# Altium schematic sheet [03] - ARDUINO SCHEMATIC.SchDoc("[03] - ARDUINO SCHEMATIC")
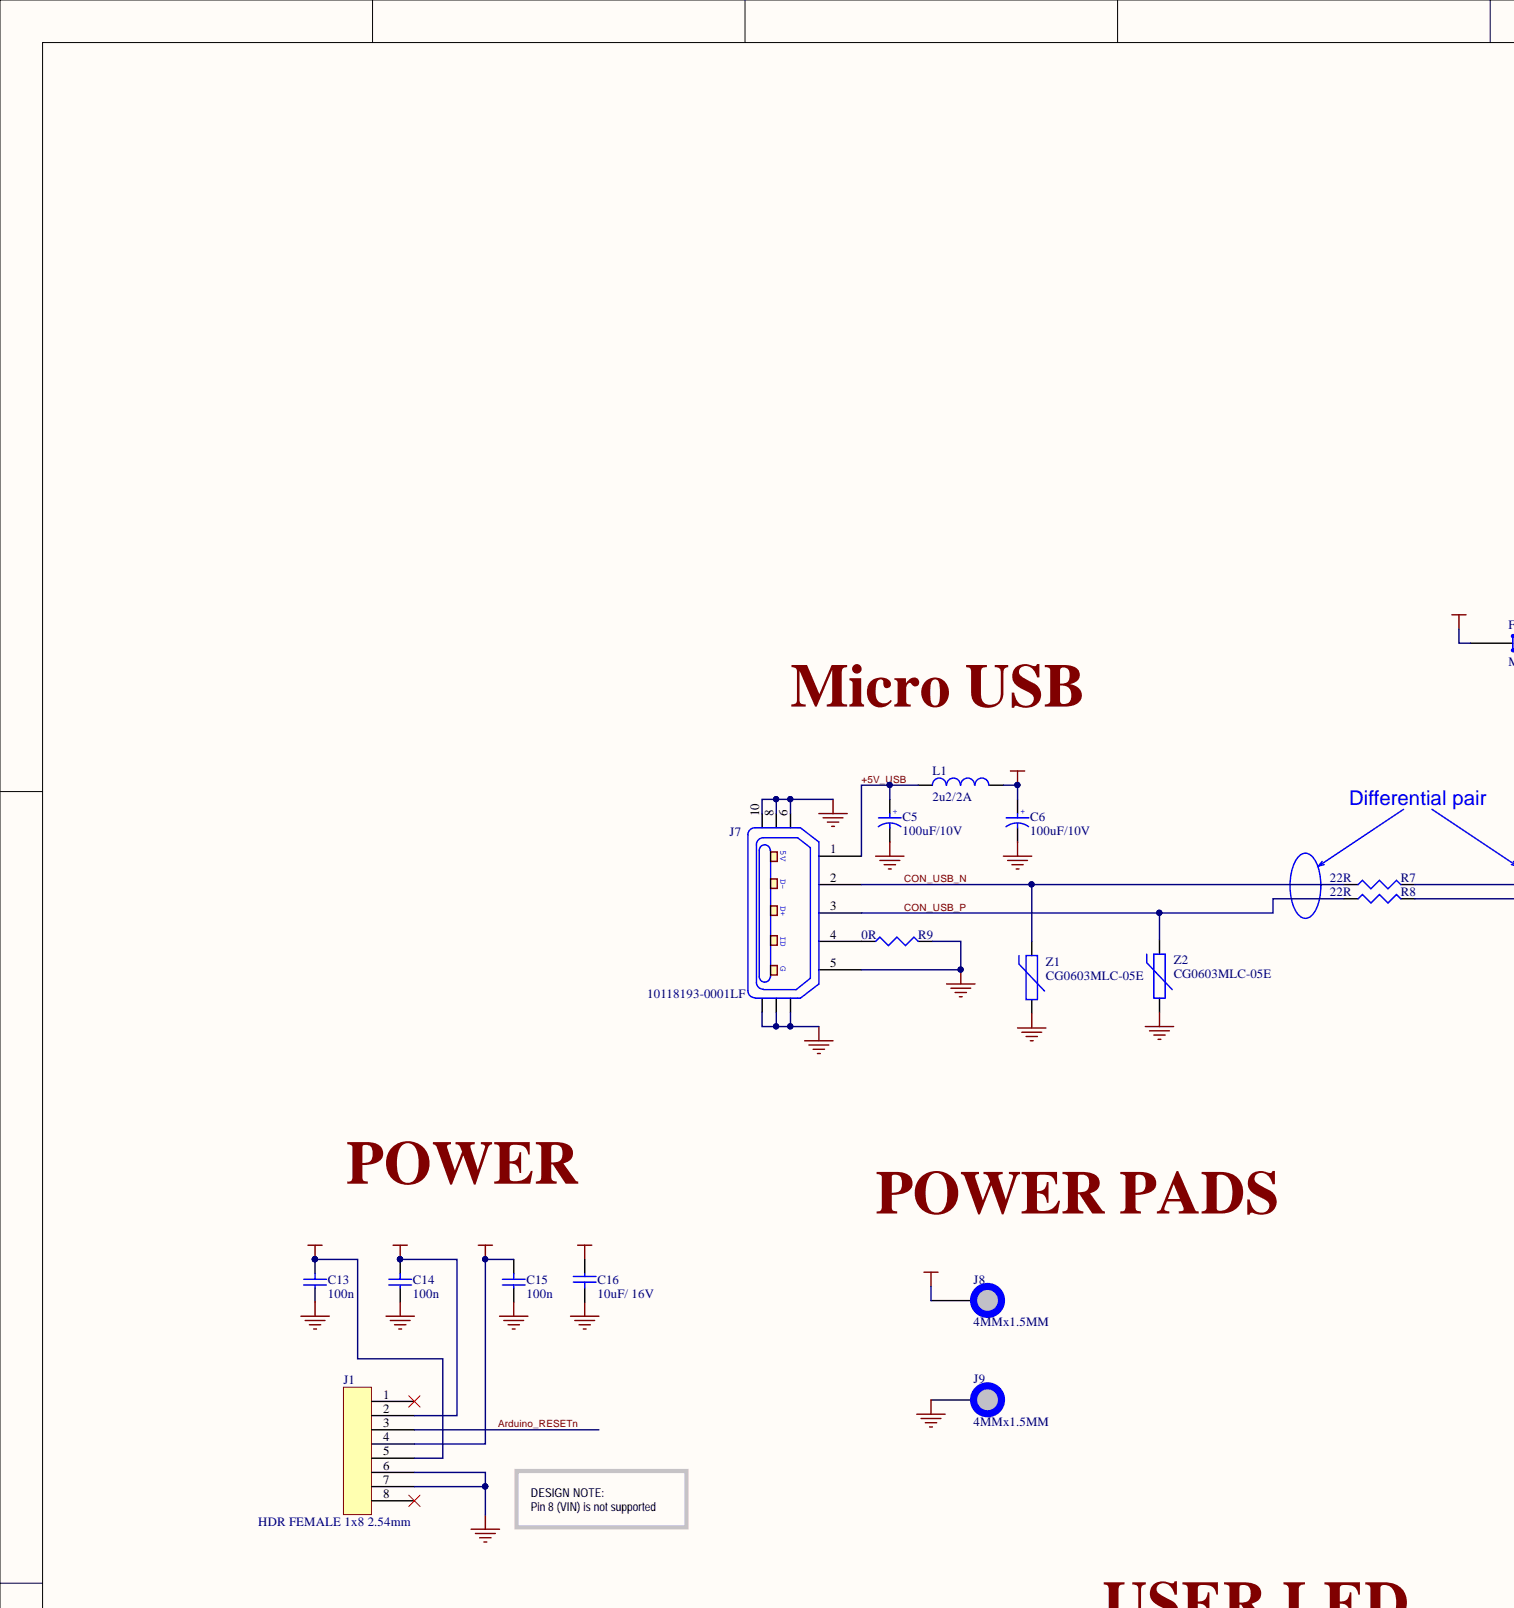
[diagram p0: [03] - ARDUINO SCHEMATIC.SchDoc, top left]
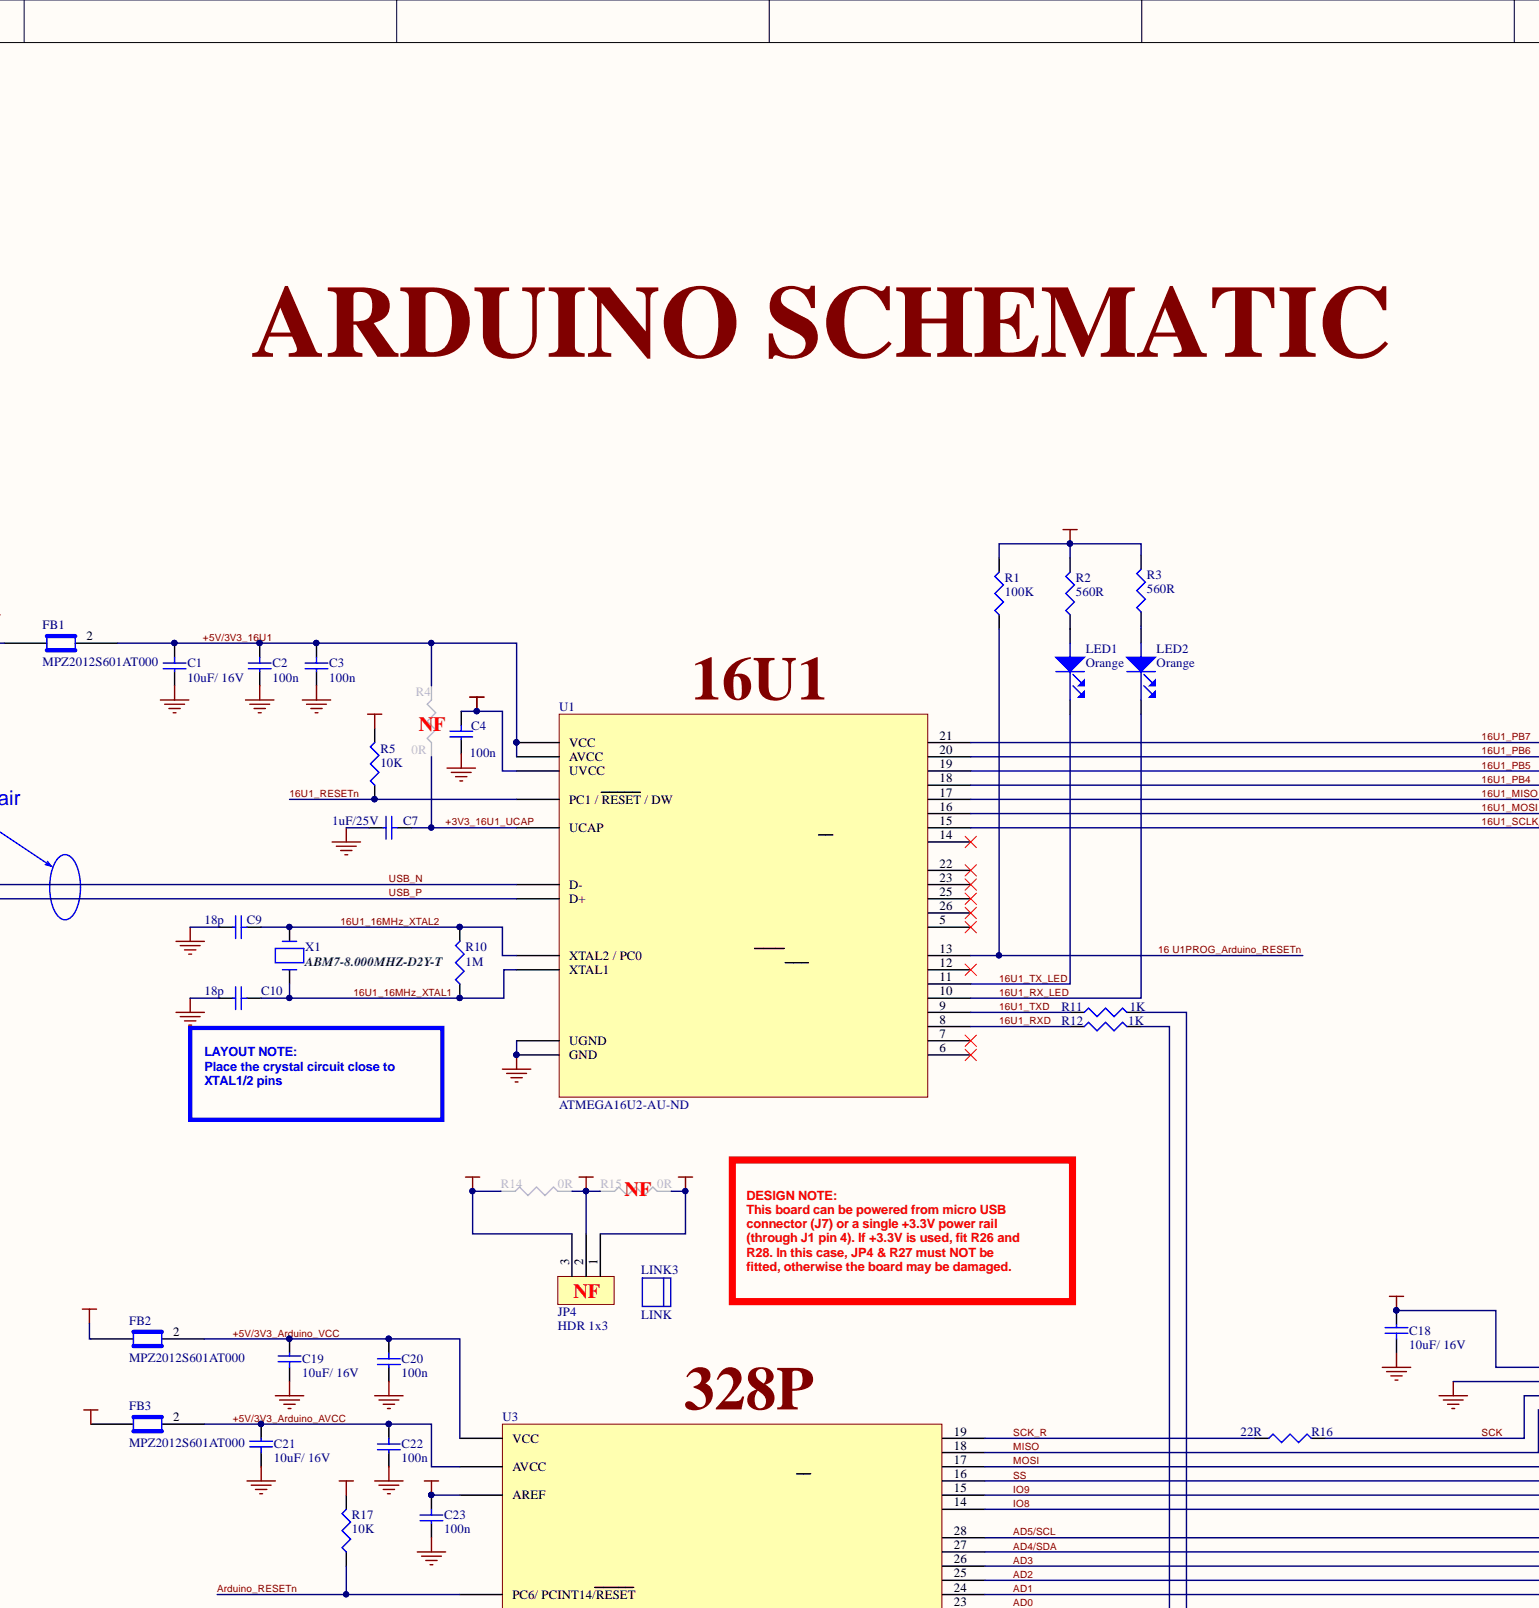
[diagram p1: [03] - ARDUINO SCHEMATIC.SchDoc, top centre]
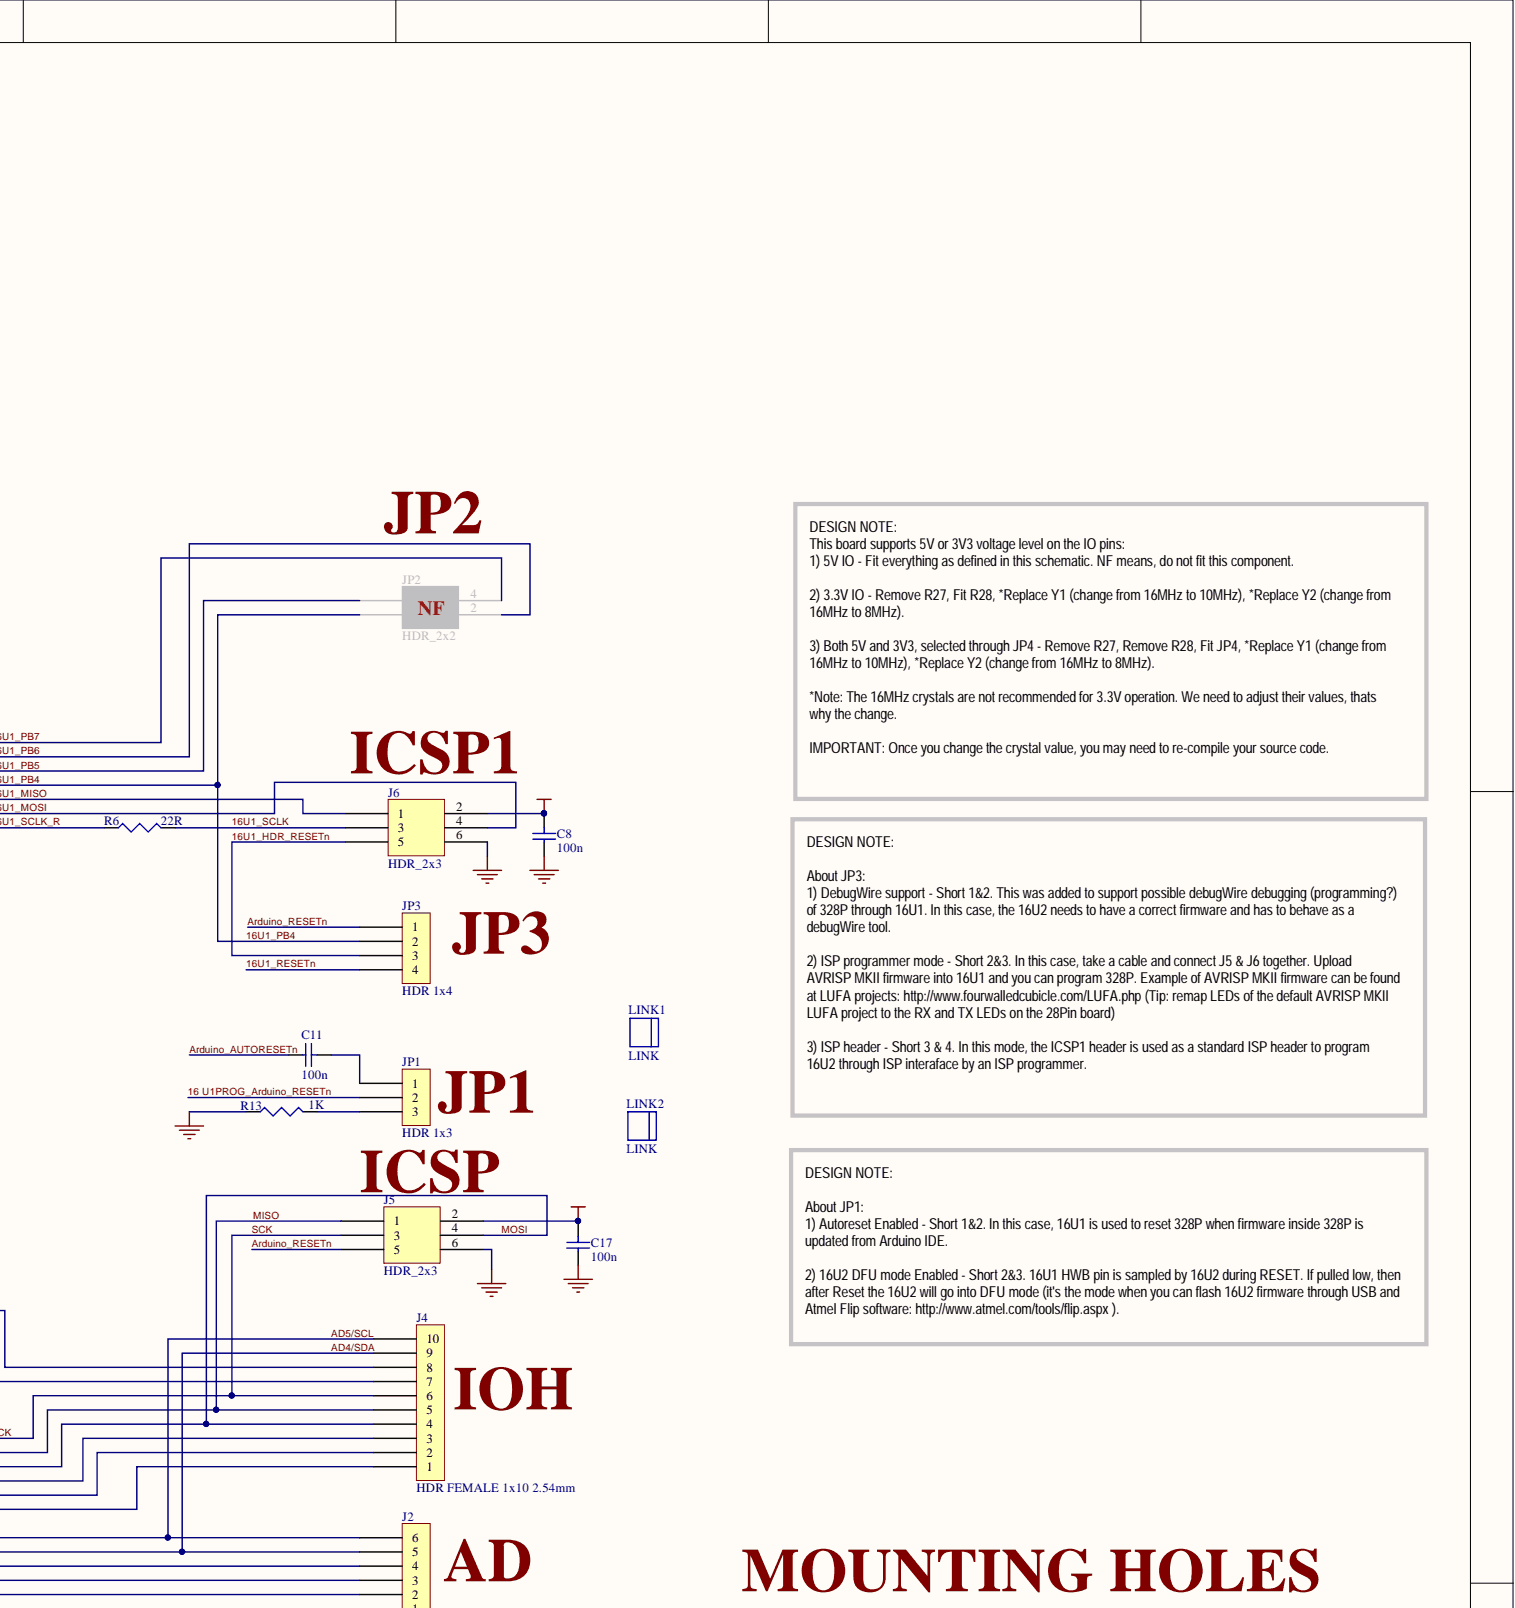
[diagram p2: [03] - ARDUINO SCHEMATIC.SchDoc, top right]
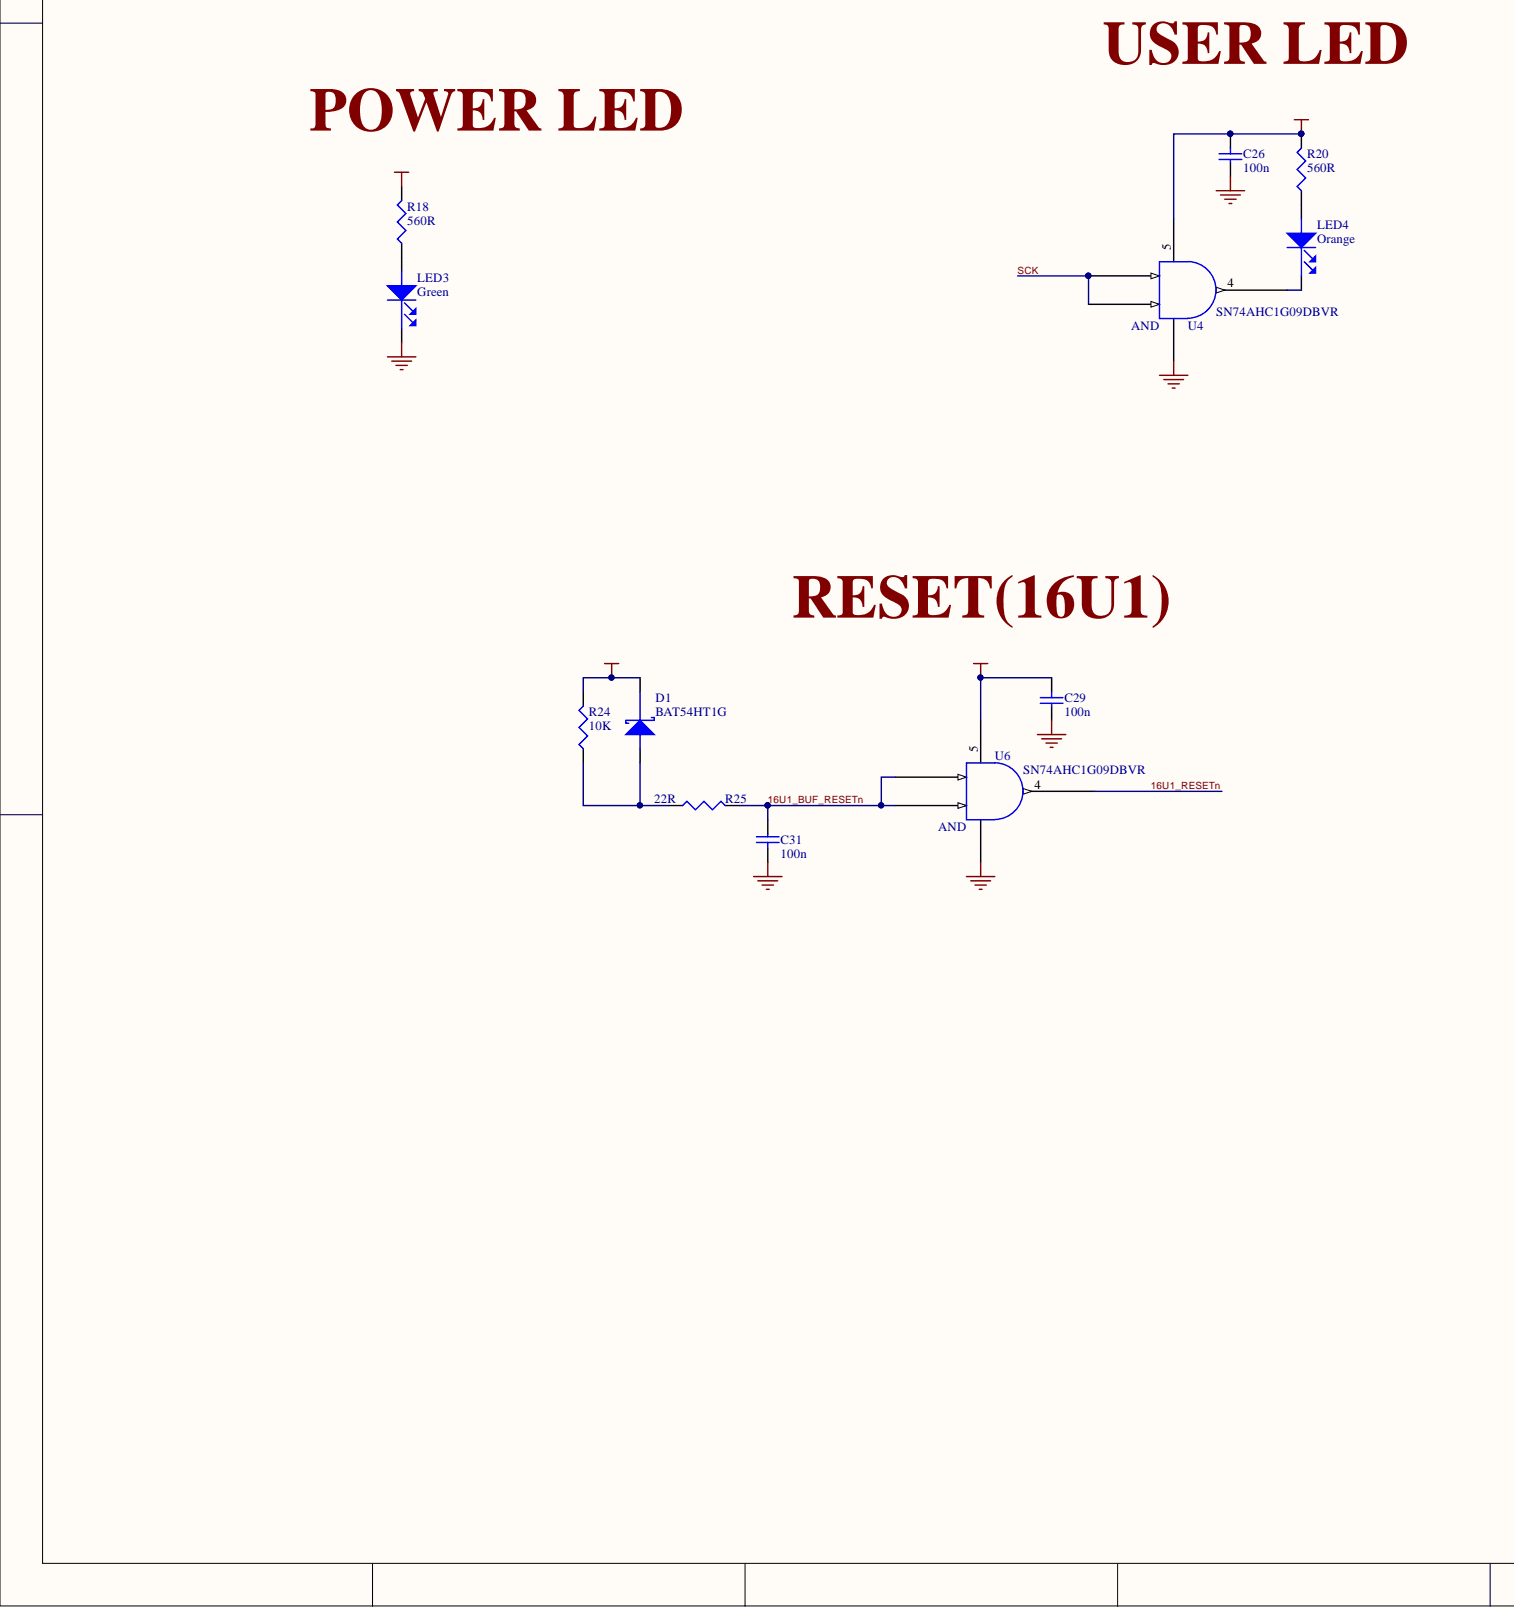
[diagram p3: [03] - ARDUINO SCHEMATIC.SchDoc, bottom left]
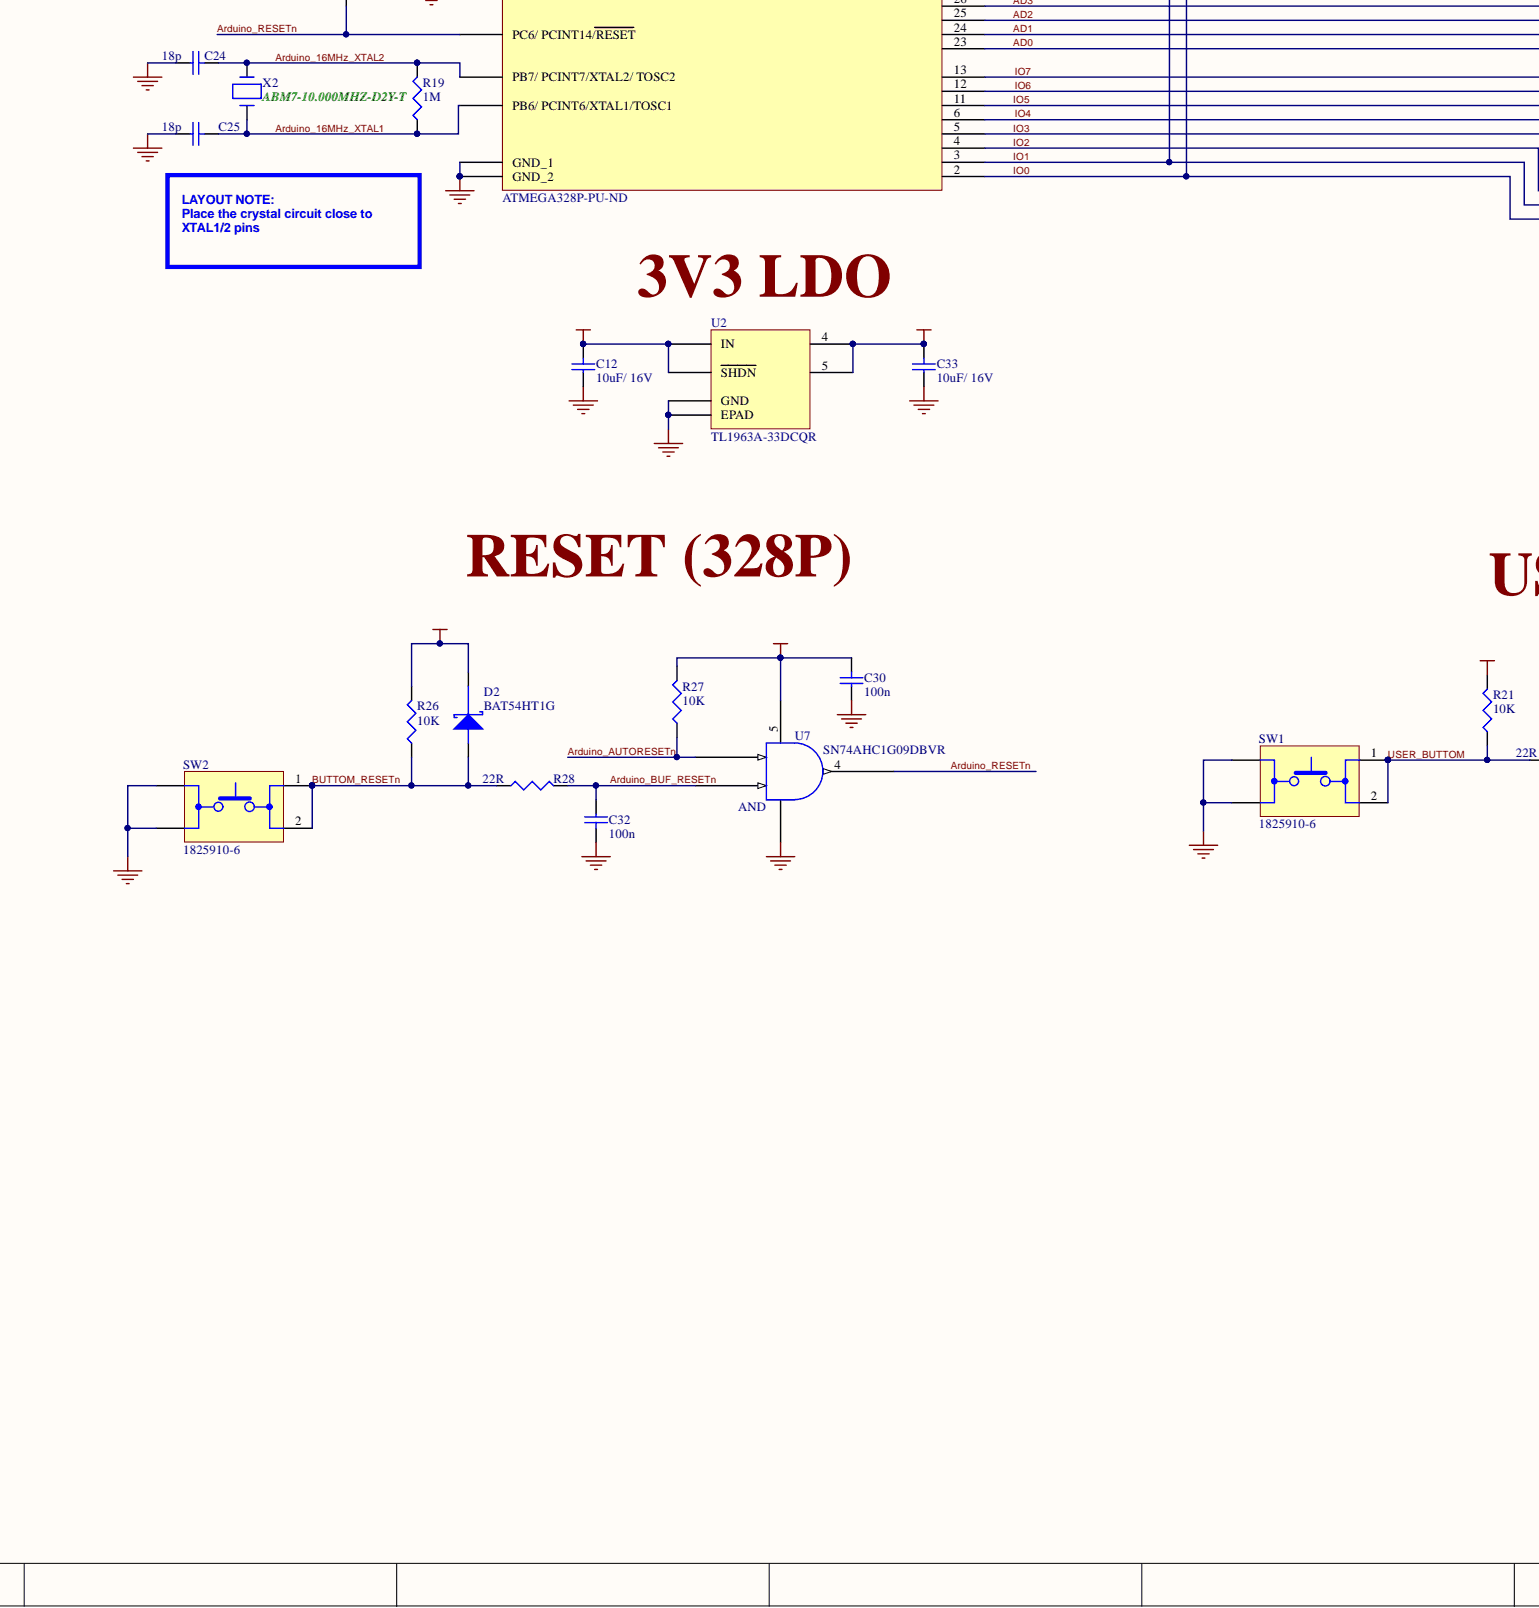
[diagram p4: [03] - ARDUINO SCHEMATIC.SchDoc, bottom centre]
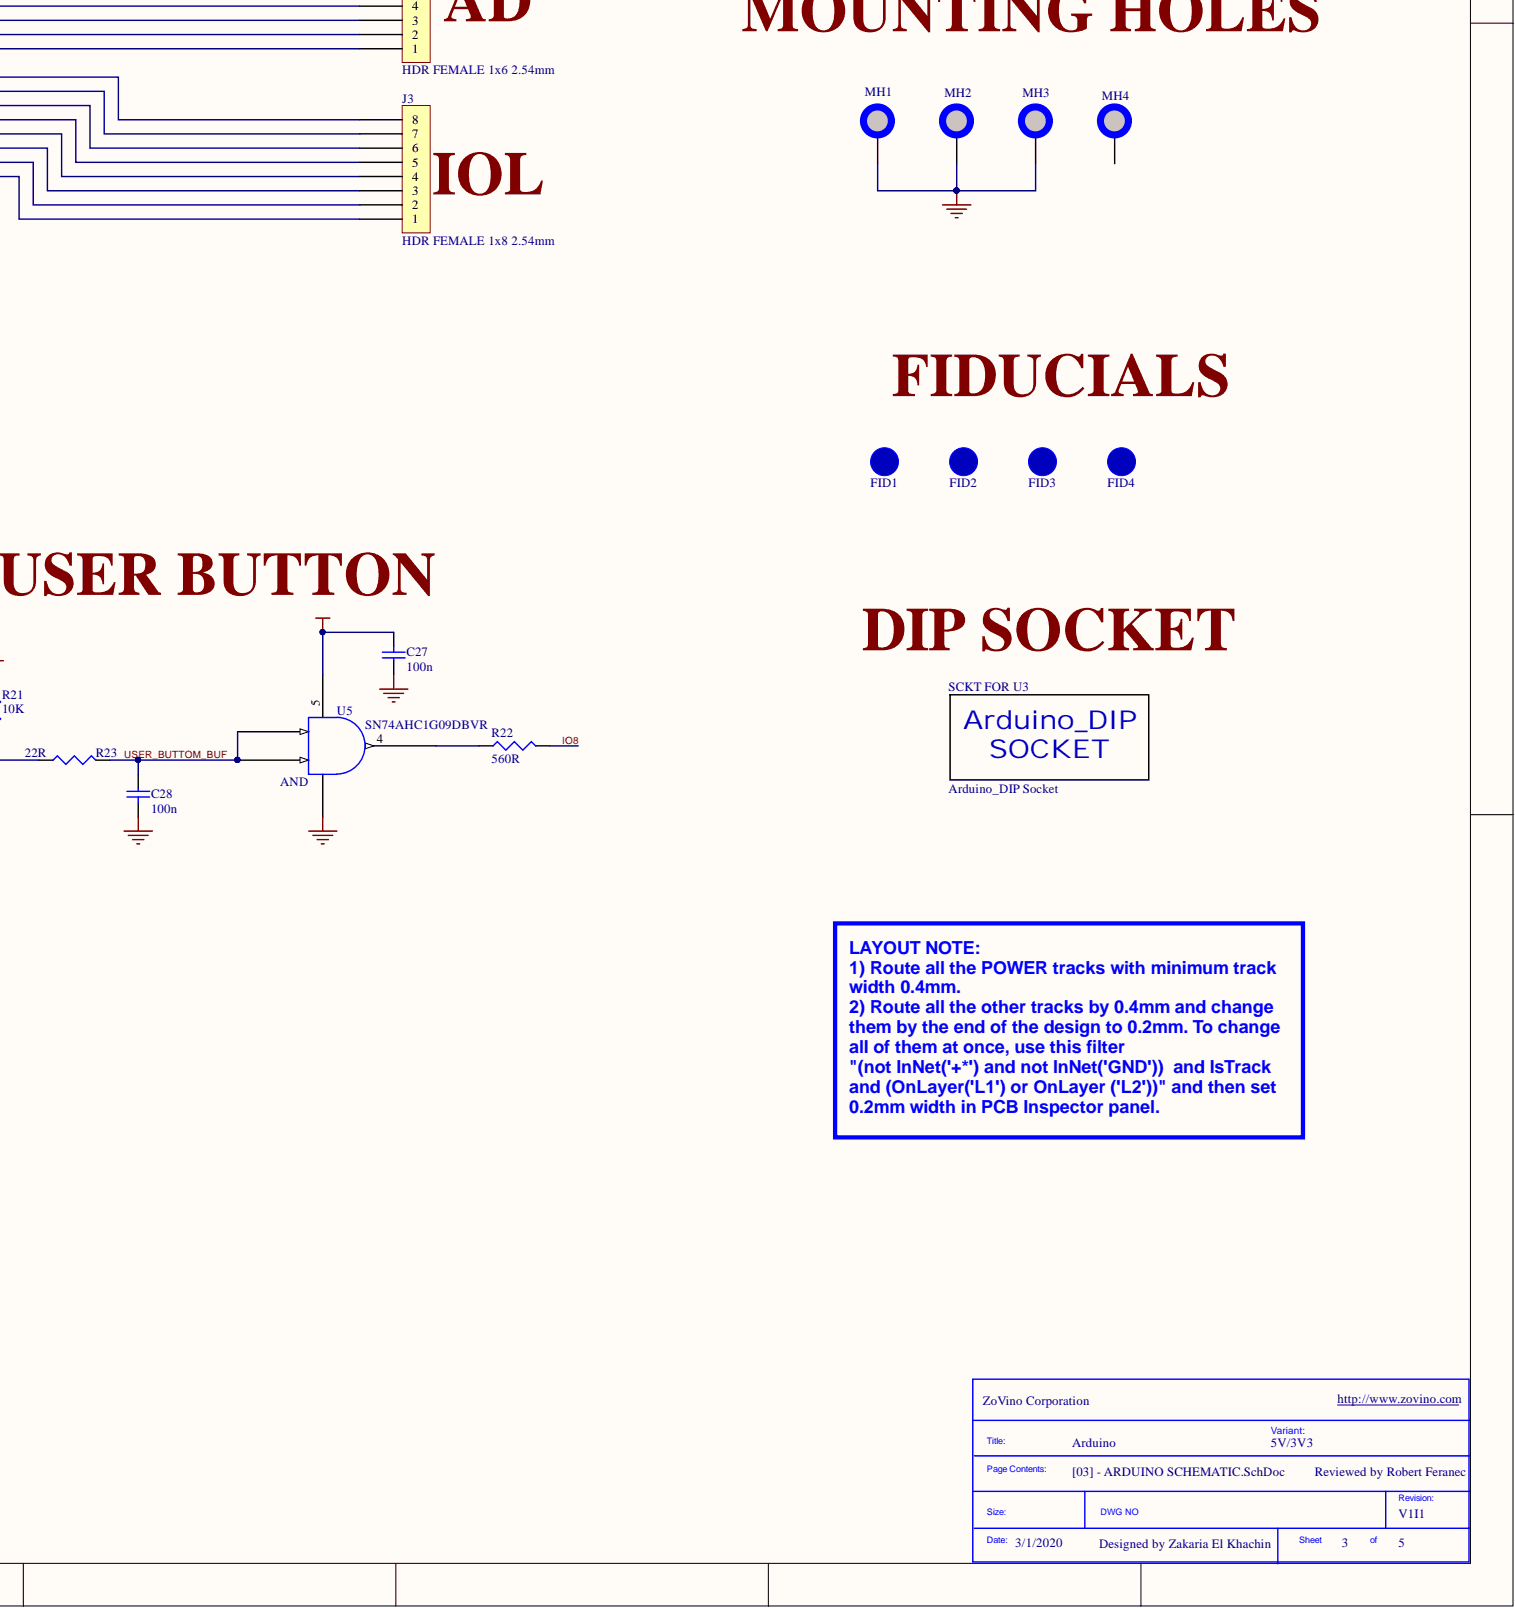
[diagram p5: [03] - ARDUINO SCHEMATIC.SchDoc, bottom right]

## Parts
C1  10uF/ 16V  [C0805]
C2  100n  [C0805]
C3  100n  [C0805]
C4  100n  [C0805]
C5  100uF/10V  [C_ALU_C_SIZE]
C6  100uF/10V  [C_ALU_C_SIZE]
C7  1uF/25V  [C0805]
C8  100n  [C0805]
C9  18p  [C0805]
C10  18p  [C0805]
C11  100n  [C0805]
C12  10uF/ 16V  Voltage - Rated: 16V  [C0805]
C13  100n  [C0805]
C14  100n  [C0805]
C15  100n  [C0805]
C16  10uF/ 16V  Voltage - Rated: 16V  [C0805]
C17  100n  [C0805]
C18  10uF/ 16V  Voltage - Rated: 16V  [C0805]
C19  10uF/ 16V  Voltage - Rated: 16V  [C0805]
C20  100n  [C0805]
C21  10uF/ 16V  Voltage - Rated: 16V  [C0805]
C22  100n  [C0805]
C23  100n  [C0805]
C24  18p  [C0805]
C25  18p  [C0805]
C26  100n  [C0805]
C27  100n  [C0805]
C28  100n  [C0805]
C29  100n  [C0805]
C30  100n  [C0805]
C31  100n  [C0805]
C32  100n  [C0805]
C33  10uF/ 16V  Voltage - Rated: 16V  [C0805]
D1  BAT54HT1G  [SOD323]
D2  BAT54HT1G  [SOD323]
FB1  MPZ2012S601AT000  [C0805]
FB2  MPZ2012S601AT000  [C0805]
FB3  MPZ2012S601AT000  [C0805]
FID1  FID_1MMC  [FID_40X120D]  (value not drawn)  (no BOM)
FID2  FID_1MMC  [FID_40X120D]  (value not drawn)  (no BOM)
FID3  FID_1MMC  [FID_40X120D]  (value not drawn)  (no BOM)
FID4  FID_1MMC  [FID_40X120D]  (value not drawn)  (no BOM)
J1  HDR FEMALE 1x8 2.54mm  [HDR_Female_1x8_2.54mm]
J2  HDR FEMALE 1x6 2.54mm  [HDR_Female_1x6_2.54mm]
J3  HDR FEMALE 1x8 2.54mm  [HDR_Female_1x8_2.54mm]
J4  HDR FEMALE 1x10 2.54mm  [HDR_Female_1x10_2.54mm]
J5  HDR_2x3  [HDR_2x3_2.5MM]
J6  HDR_2x3  [HDR_2x3_2.5MM]
J7  10118193-0001LF  [10118193]
J8  4MMx1.5MM  [PAD_WITH_HOLE_4MMx1.5MM]  (no BOM)
J9  4MMx1.5MM  [PAD_WITH_HOLE_4MMx1.5MM]  (no BOM)
JP1  HDR 1x3  [HDR_1x3_2.54mm]
JP2  HDR_2x2  [HDR_2x2_2.5MM]
JP3  HDR 1x4  Gender: HDR  [HDR_1x4_2.54mm]
JP4  HDR 1x3  [HDR_1x3_2.54mm]
L1  2u2/2A  [C210_IND]
LED1  Orange  [LED_ 0603]
LED2  Orange  [LED_ 0603]
LED3  Green  [LED_ 0603]
LED4  Orange  [LED_ 0603]
LINK1  LINK  (mechanical)
LINK2  LINK  (mechanical)
LINK3  LINK  (mechanical)
MH1  MH_7.4MMC_3.2MMD  [MH_7.4MMC_3.2MMD]  (value not drawn)  (no BOM)
MH2  MH_7.4MMC_3.2MMD  [MH_7.4MMC_3.2MMD]  (value not drawn)  (no BOM)
MH3  MH_7.4MMC_3.2MMD  [MH_7.4MMC_3.2MMD]  (value not drawn)  (no BOM)
MH4  MH_7.4MMNC_3.2MMD  [MH_7.4MMD_3.2MMD_NON_COPPER_NPTH]  (value not drawn)  (no BOM)
R1  100K  [R0805]
R2  560R  [R0805]
R3  560R  [R0805]
R4  0R  [R0805]
R5  10K  [R0805]
R6  22R  [R0805]
R7  22R  [R0805]
R8  22R  [R0805]
R9  0R  [R0805]
R10  1M  [R0805]
R11  1K  [R0805]
R12  1K  [R0805]
R13  1K  [R0805]
R14  0R  [R0805]
R15  0R  [R0805]
R16  22R  [R0805]
R17  10K  [R0805]
R18  560R  [R0805]
R19  1M  [R0805]
R20  560R  [R0805]
R21  10K  [R0805]
R22  560R  [R0805]
R23  22R  [R0805]
R24  10K  [R0805]
R25  22R  [R0805]
R26  10K  [R0805]
R27  10K  [R0805]
R28  22R  [R0805]
SCKT_FOR_U3  Arduino_DIP Socket  Socket / Case Type: DIP  [Arduino_DIP_7.62mm_SOCKET]
SW1  1825910-6  [1825910-6]
SW2  1825910-6  [1825910-6]
U1  ATMEGA16U2-AU-ND  [TQFP32]
U2  TL1963A-33DCQR  Voltage Regulator Type: LDO  [DCQ (R-PDSO-G6)]
U3  ATMEGA328P-PU-ND  [28_DIP_7.62mm]
U4  SN74AHC1G09DBVR  [DBV_SOT_23_5]
U5  SN74AHC1G09DBVR  [DBV_SOT_23_5]
U6  SN74AHC1G09DBVR  [DBV_SOT_23_5]
U7  SN74AHC1G09DBVR  [DBV_SOT_23_5]
X1  ABM7-8.000MHZ-D2Y-T  [ABM7_v1_BIG]
X1
X2  ABM7-10.000MHZ-D2Y-T  [ABM7_v1_BIG]
X2  ABM7-10.000MHZ-D2Y-T  [ABM7_v1_BIG]
Z1  CG0603MLC-05E  [R0603]
Z2  CG0603MLC-05E  [R0603]

## Nets
+3V3: C15.2 C33.2 J1.4 JP4.1 R15.2 U2.4 U2.5
+5V: C4.2 C6.1 C12.2 C13.2 J1.5 J8.1 JP4.3 L1.2 R14.1 U1.31(UVCC) U2.1(SHDN) U2.2(IN)
+5V/3V3: C8.2 C14.2 C16.1 C17.2 C26.2 C27.2 C29.2 C30.2 D1.1 D2.1 FB1.1 FB2.1 FB3.1 J1.2 J5.2 J6.2 JP4.2 R1.2 R2.2 R3.2 R5.2 R14.2 R15.1 R17.2 R18.2 R20.2 R21.2 R24.2 R26.2 R27.2 U4.5 U5.5 U6.5 U7.5
+AREF: C18.1 C23.2 J4.8 U3.21(AREF)
GND: C1.1 C2.1 C3.1 C4.1 C5.2 C6.2 C7.1 C8.1 C9.1 C10.1 C12.1 C13.1 C14.1 C15.1 C16.2 C17.1 C18.2 C19.2 C20.1 C21.2 C22.1 C23.1 C24.1 C25.1 C26.1 C27.1 C28.2 C29.1 C30.1 C31.2 C32.2 C33.1 J1.6 J1.7 J4.7 J5.6 J6.6 J7.5 J7.6 J7.7 J7.8 J7.9 J7.10 J7.11 J9.1 LED3.1 MH1.1 MH2.1 MH3.1 R9.2 R13.1 SW1.3 SW1.4 SW2.3 SW2.4 U1.3(GND) U1.28(UGND) U2.3(GND) U2.6(EPAD) U3.8(GND_1) U3.22(GND_2) U4.3 U5.3 U6.3 U7.3 Z1.1 Z2.1
16 U1PROG_Arduino_RESETn: JP1.2 R1.1 U1.13
16U1_16MHz_XTAL1: C10.2 R10.1 U1.1(XTAL1) X1.2
16U1_16MHz_XTAL2: C9.2 R10.2 U1.2(XTAL2_/_PC0) X1.1
16U1_BUF_RESETn: C31.1 R25.2 U6.1 U6.2
16U1_HDR_RESETn: J6.5 JP3.3
16U1_MISO: J6.1 U1.17
16U1_MOSI: J6.4 U1.16
16U1_PB4: JP2.1 JP3.2 U1.18
16U1_PB5: JP2.3 U1.19
16U1_PB6: JP2.2(NF) U1.20
16U1_PB7: JP2.4 U1.21
16U1_RESETn: JP3.4 R5.1 U1.24(PC1_/_RESET_/_DW) U6.4
16U1_RX_LED: LED2.1 U1.10
16U1_RXD: R12.1 U1.8
16U1_SCLK: J6.3 R6.2
16U1_SCLK_R: R6.1 U1.15
16U1_TX_LED: LED1.1 U1.11
16U1_TXD: R11.1 U1.9
+3V3_16U1_UCAP: C7.2 R4.1 U1.27(UCAP)
+5V/3V3_16U1: C1.2 C2.2 C3.2 FB1.2 R4.2 U1.4(VCC) U1.32(AVCC)
+5V/3V3_Arduino_AVCC: C21.1 C22.2 FB3.2 U3.20(AVCC)
+5V/3V3_Arduino_VCC: C19.1 C20.2 FB2.2 U3.7(VCC)
+5V_USB: C5.1 J7.1 L1.1
AD0: J2.1 U3.23
AD1: J2.2 U3.24
AD2: J2.3 U3.25
AD3: J2.4 U3.26
AD4/SDA: J2.5 J4.9 U3.27
AD5/SCL: J2.6 J4.10 U3.28
Arduino_16MHz_XTAL1: C25.2 R19.1 U3.9(PB6/_PCINT6/XTAL1/TOSC1) X2.2
Arduino_16MHz_XTAL2: C24.2 R19.2 U3.10(PB7/_PCINT7/XTAL2/_TOSC2) X2.1
Arduino_AUTORESETn: C11.1 R27.1 U7.1
Arduino_BUF_RESETn: C32.1 R28.2 U7.2
Arduino_RESETn: J1.3 J5.5 JP3.1 R17.1 U3.1(PC6/_PCINT14/RESET) U7.4
BUTTOM_RESETn: D2.2 R26.1 R28.1 SW2.1 SW2.2
CON_USB_N: J7.2 R7.1 Z1.2
CON_USB_P: J7.3 R8.1 Z2.2
IO0: J3.1 R11.2 U3.2
IO1: J3.2 R12.2 U3.3
IO2: J3.3 U3.4
IO3: J3.4 U3.5
IO4: J3.5 U3.6
IO5: J3.6 U3.11
IO6: J3.7 U3.12
IO7: J3.8 U3.13
IO8: J4.1 R22.2 U3.14
IO9: J4.2 U3.15
MISO: J4.5 J5.1 U3.18
MOSI: J4.4 J5.4 U3.17
SCK: J4.6 J5.3 R16.2 U4.1 U4.2
SCK_R: R16.1 U3.19
SS: J4.3 U3.16
USB_N: R7.2 U1.30(D-)
USB_P: R8.2 U1.29(D+)
USER_BUTTOM: R21.1 R23.1 SW1.1 SW1.2
USER_BUTTOM_BUF: C28.1 R23.2 U5.1 U5.2
NetC11_2: C11.2 JP1.1
NetD1_2: D1.2 R24.1 R25.1
NetJ7_4: J7.4 R9.1
NetJP1_3: JP1.3 R13.2
NetLED1_2: LED1.2 R2.1
NetLED2_2: LED2.2 R3.1
NetLED3_2: LED3.2 R18.1
NetLED4_1: LED4.1 U4.4
NetLED4_2: LED4.2 R20.1
NetR22_1: R22.1 U5.4

Not connected: J1 1 8; MH4 1; U1 5 6 7 12 14 22 23 25 26
